# Altium schematic sheet 06_ATK_DLRK3506J_NET1.SchDoc
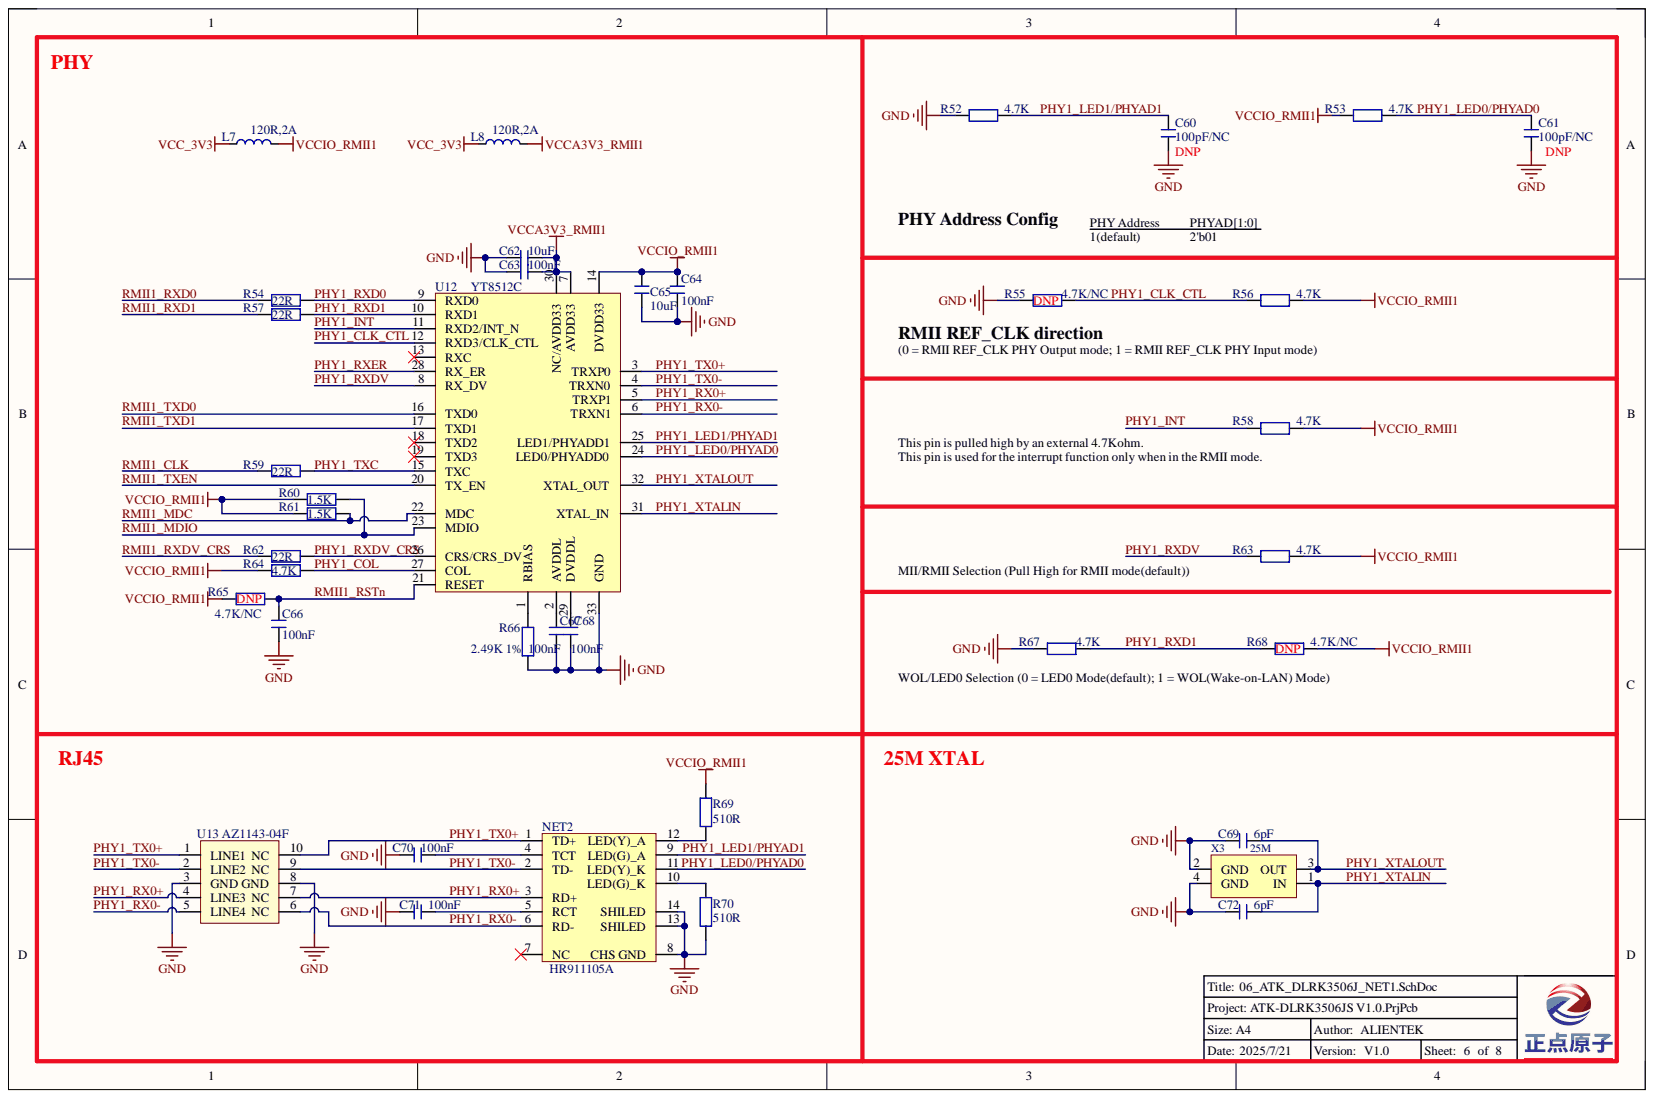
[diagram p0: 06_ATK_DLRK3506J_NET1.SchDoc]

## Parts
C60  100pF/NC  [C 0402]
C61  100pF/NC  [C 0402]
C62  10uF  [C 0402]
C63  100nF  [C 0402]
C64  100nF  [C 0402]
C65  10uF  [C 0402]
C66  100nF  [C 0402]
C67  100nF  [C 0402]
C68  100nF  [C 0402]
C69  6pF  [C 0402]
C70  100nF  [C 0402]
C71  100nF  [C 0402]
C72  6pF  [C 0402]
L7  120R,2A  [L 0603]
L8  120R,2A  [L 0603]
NET2  HR911105A  [HR911105A]
R52  4.7K  [R 0402]
R53  4.7K  [R 0402]
R54  22R  [R 0402]
R55  4.7K/NC  [R 0402]
R56  4.7K  [R 0402]
R57  22R  [R 0402]
R58  4.7K  [R 0402]
R59  22R  [R 0402]
R60  1.5K  [R 0402]
R61  1.5K  [R 0402]
R62  22R  [R 0402]
R63  4.7K  [R 0402]
R64  4.7K  [R 0402]
R65  4.7K/NC  [R 0402]
R66  2.49K 1%  [R 0402]
R67  4.7K  [R 0402]
R68  4.7K/NC  [R 0402]
R69  510R  [R 0402]
R70  510R  [R 0402]
U12  YT8512C  [YT8512C]
U13  AZ1143-04F  [DFN2510P10E]
X3  25M  [XTAL-3225]

## Nets
GND: C60.2 C61.2 C62.1 C63.2 C64.1 C65.2 C66.1 C67.1 C68.1 C69.2 C70.2 C71.2 C72.2 NET2.8(CHS_GND) NET2.13(SHILED) NET2.14(SHILED) R52.2 R55.2 R66.2 R67.2 R70.2 U12.33(GND) U13.3(GND) U13.8(GND) X3.2(GND) X3.4(GND)
VCC_3V3: L7.1 L8.1
VCCA3V3_RMII1: C62.2 C63.1 L8.2 U12.7(AVDD33) U12.30(NC/AVDD33)
VCCIO_RMII1: C64.2 C65.1 L7.2 R53.2 R56.1 R58.1 R60.2 R61.2 R63.1 R64.2 R65.2 R68.1 R69.2 U12.14(DVDD33)
PHY1_CLK_CTL: R55.1 R56.2 U12.12(RXD3/CLK_CTL)
PHY1_COL: R64.1 U12.27(COL)
PHY1_INT: R58.2 U12.11(RXD2/INT_N)
PHY1_LED0/PHYAD0: C61.1 NET2.11(LED(Y)_K) R53.1 U12.24(LED0/PHYADD0)
PHY1_LED1/PHYAD1: C60.1 NET2.9(LED(G)_A) R52.1 U12.25(LED1/PHYADD1)
PHY1_RX0+: NET2.3(RD+) U12.5(TRXP1) U13.4(LINE3) U13.7(NC)
PHY1_RX0-: NET2.6(RD-) U12.6(TRXN1) U13.5(LINE4) U13.6(NC)
PHY1_RXD0: R54.1 U12.9(RXD0)
PHY1_RXD1: R57.1 R67.1 R68.2 U12.10(RXD1)
PHY1_RXDV: R63.2 U12.8(RX_DV)
PHY1_RXDV_CRS: R62.1 U12.26(CRS/CRS_DV)
PHY1_RXER: U12.28(RX_ER)
PHY1_TX0+: NET2.1(TD+) U12.3(TRXP0) U13.1(LINE1) U13.10(NC)
PHY1_TX0-: NET2.2(TD-) U12.4(TRXN0) U13.2(LINE2) U13.9(NC)
PHY1_TXC: R59.1 U12.15(TXC)
PHY1_XTALIN: C72.1 U12.31(XTAL_IN) X3.1(IN)
PHY1_XTALOUT: C69.1 U12.32(XTAL_OUT) X3.3(OUT)
RMII1_CLK: R59.2
RMII1_MDC: R61.1 U12.22(MDC)
RMII1_MDIO: R60.1 U12.23(MDIO)
RMII1_RSTn: C66.2 R65.1 U12.21(RESET)
RMII1_RXD0: R54.2
RMII1_RXD1: R57.2
RMII1_RXDV_CRS: R62.2
RMII1_TXD0: U12.16(TXD0)
RMII1_TXD1: U12.17(TXD1)
RMII1_TXEN: U12.20(TX_EN)
NetC67_2: C67.2 U12.2(AVDDL)
NetC68_2: C68.2 U12.29(DVDDL)
NetC70_1: C70.1 NET2.4(TCT)
NetC71_1: C71.1 NET2.5(RCT)
NetNET2_10: NET2.10(LED(G)_K) R70.1
NetNET2_12: NET2.12(LED(Y)_A) R69.1
NetR66_1: R66.1 U12.1(RBIAS)

Not connected: NET2 7(NC); U12 13(RXC) 18(TXD2) 19(TXD3)

## Library data of the parts
C60-C72 = C: 无极性贴片电容; 版权归属: 源创客; BOM名称: 无极性贴片电容; Component Kind: Standard; Library Name: 电阻-电容-电感.SchLib; 学习资源: www.ooocke.com
L7 L8 = L: 小功率贴片电感磁珠; 版权归属: 正点原子; BOM名称: 小功率贴片电感; Component Kind: Standard; Library Name: 电阻-电容-电感.SchLib; 学习资源: www.openedv.com
NET2 = HR911105: Component Kind: Standard; Library Name: MYCOMLIB.IntLib
R52-R70 = R: 贴片电阻; BOM名称: 电阻; 版权归属: 正点原子; Component Kind: Standard; Library Name: 电阻-电容-电感.SchLib; 学习资源: www.openedv.com
U12 = YT8512C: 10M/100M 网络PHY RMII/MII; Component Kind: Standard; Library Name: ALIENTEK_COMPONENT.IntLib
U13 = AZ1143-04F: ESD保护二极管阵列; Component Kind: Standard; Library Name: 二极管-整流桥.SchLib
X3 = XTAL-4P: 4脚无源晶振; BOM名称: 4脚晶振; 版权归属: 源创客; Component Kind: Standard; 学习资源: www.ooocke.com; Library Name: Lycreturn.IntLib
